# Altium schematic sheet RF_Rev0.SchDoc(RF_Rev0)
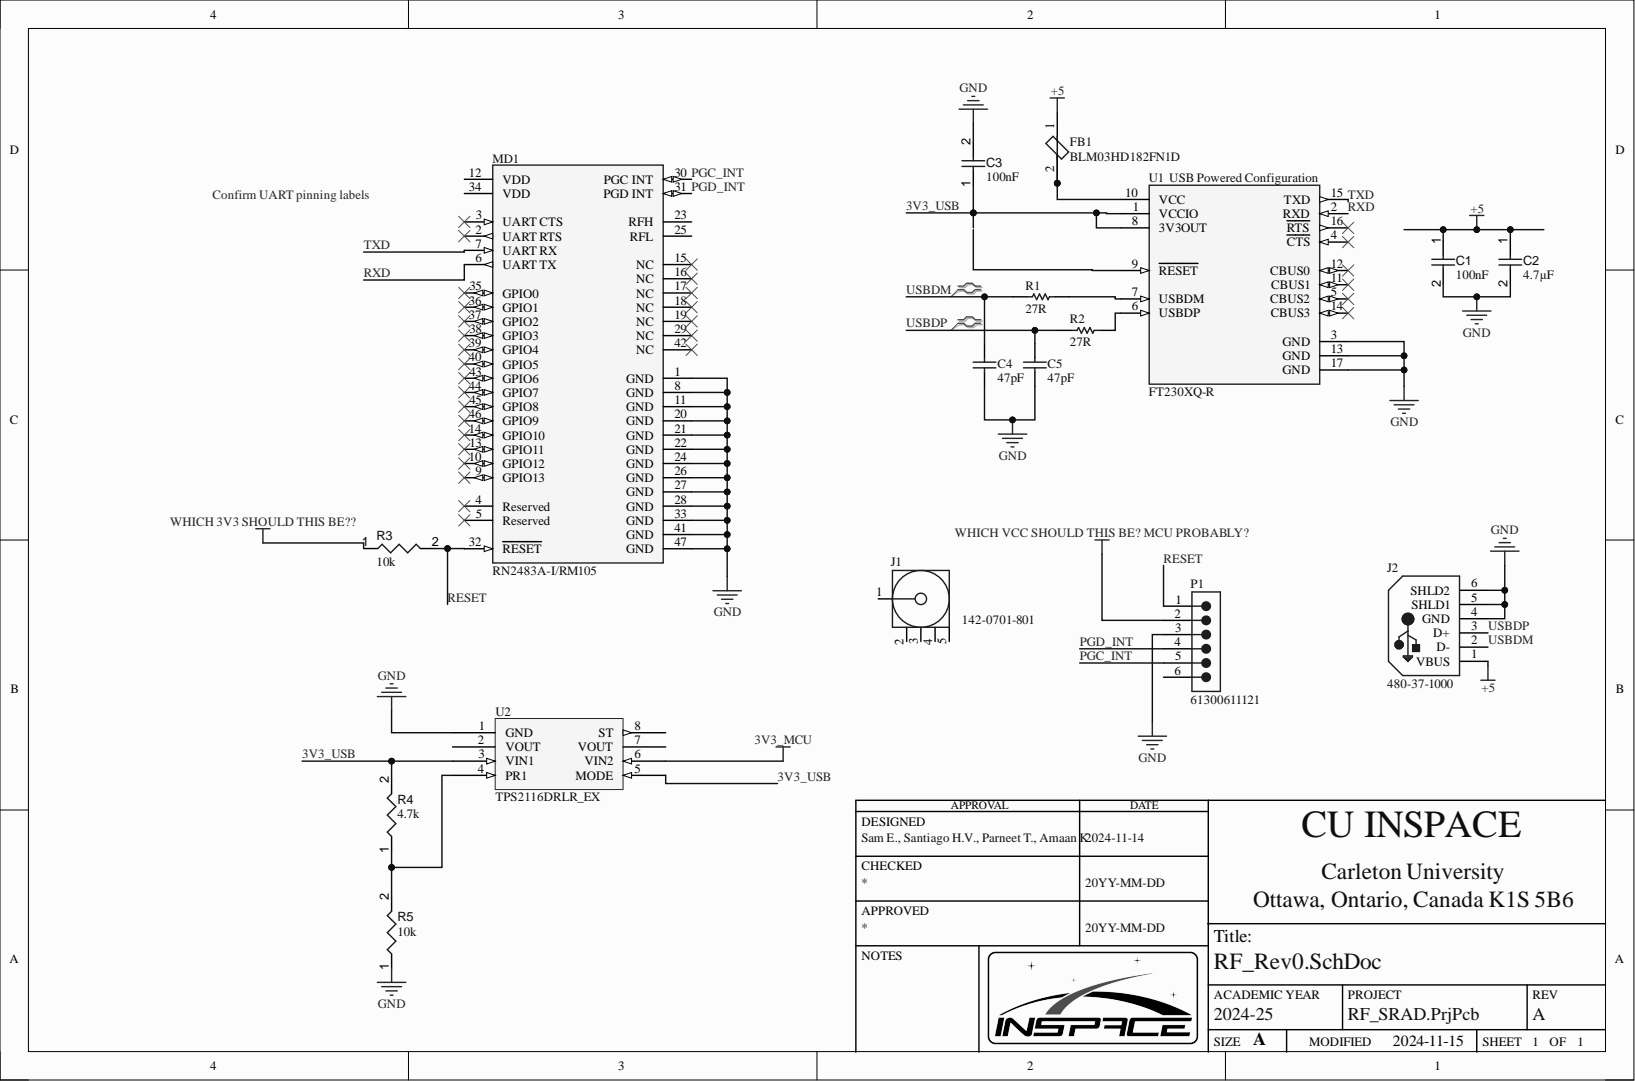
[diagram p0: RF_Rev0.SchDoc]

## Parts
C1  value 100nF  [CAPC0402(1005)60_L]  (hidden comment: Capacitor 100nF +/-20% 25V 0402)
C2  value 4.7µF  [CAPC0603(1608)100_L]  (hidden comment: Capacitor 4.7µF +/-20% 10V 0603)
C3  value 100nF  [CAPC0402(1005)60_L]  (hidden comment: Capacitor 100nF +/-20% 25V 0402)
C4  47pF  [CAPC1005X55X25LL05T10]
C5  47pF  [CAPC1005X55X25LL05T10]
FB1  BLM03HD182FN1D  [FP-BLM03-0_03-d0_3-IPC_A]
J1  142-0701-801  [CINC-142-0701-801_V]
J2  480-37-1000  [MOLX-480-37-1000_V]
MD1  RN2483A-I/RM105  [FP-RN2483A-I-RM105-MFG]
P1  61300611121  [61300611121]
R1  27R  [RESC1005X40X25LL05T10]
R2  27R  [RESC1005X40X25LL05T10]
R3  value 10k  [RESC1005X40X25ML05T05]  (hidden comment: Resistor 10k +/-1% 0402 63 mW)
R4  value 4.7k  [RESC3116X70X50ML13T20]  (hidden comment: Resistor 4k7 +/-1% 1206 250 mW)
R5  value 10k  [RESC1608X55X25ML10T15]  (hidden comment: Resistor 10k +/-1% 0603 100 mW)
U1  FT230XQ-R  Interface: USB  [FP-QFN-16-MFG]
U2  TPS2116DRLR_EX  [TPS2115]

## Nets
3V3_MCU: U2.6(VIN2)
+5: C1.1 C2.1 FB1.1 J2.1(VBUS)
GND: C1.2 C2.2 C3.2 C4.1 C5.1 J2.4(GND) J2.5(SHLD1) J2.6(SHLD2) MD1.1(GND) MD1.8(GND) MD1.11(GND) MD1.20(GND) MD1.21(GND) MD1.22(GND) MD1.24(GND) MD1.26(GND) MD1.27(GND) MD1.28(GND) MD1.33(GND) MD1.41(GND) MD1.47(GND) P1.3 R5.1 U1.3(GND) U1.13(GND) U1.17(GND) U2.1(GND)
WHICH 3V3 SHOULD THIS BE??: R3.1
WHICH VCC SHOULD THIS BE? MCU PROBABLY?: P1.2
3V3_USB: C3.1 R4.2 U1.1(VCCIO) U1.8(3V3OUT) U2.3(VIN1) U2.5(MODE)
PGC_INT: MD1.30(PGC_INT) P1.5
PGD_INT: MD1.31(PGD_INT) P1.4
RESET: MD1.32(RESET) P1.1 R3.2
RXD: MD1.6(UART_TX) U1.2(RXD)
TXD: MD1.7(UART_RX) U1.15(TXD)
USBDM: C4.2 J2.2(D-) R1.1
USBDP: C5.2 J2.3(D+) R2.1
NetFB1_2: FB1.2 U1.10(VCC)
NetR1_2: R1.2 U1.7(USBDM)
NetR2_2: R2.2 U1.6(USBDP)
NetR4_1: R4.1 R5.2 U2.4(PR1)
Confirm UART pinning labels:

Not connected: J1 1 2 3 4 5; MD1 2(UART_RTS) 3(UART_CTS) 4(Reserved) 5(Reserved) 9(GPIO13) 10(GPIO12) 12(VDD) 13(GPIO11) 14(GPIO10) 15(NC) 16(NC) 17(NC) 18(NC) 19(NC) 23(RFH) 25(RFL) 29(NC) 34(VDD) 35(GPIO0) 36(GPIO1) 37(GPIO2) 38(GPIO3) 39(GPIO4) 40(GPIO5) 42(NC) 43(GPIO6) 44(GPIO7) 45(GPIO8) 46(GPIO9); P1 6; U1 4(CTS) 5(CBUS2) 9(RESET) 11(CBUS1) 12(CBUS0) 14(CBUS3) 16(RTS); U2 2(VOUT) 7(VOUT) 8(ST)

## Library data of the parts
C1 C3 = CMP-001-00065-4: Chip Capacitor, 100nF +/-20%, 25V, 0402, Thickness 0.6 mm; Tolerance: 10%; Case/Package: 0402; Component Kind: Standard; Max Operating Temperature: 85°C; Min Operating Temperature: -55°C; Mounting Technology: SMT; Pins: 2; Voltage Rating: 25V; Library Name: Americas- CU InSpace-  Carleton University
C2 = CMP-001-00076-4: Chip Capacitor, 4.7µF +/-20%, 10V, 0603, Thickness 1 mm; Tolerance: 10%; Case/Package: 0603; Component Kind: Standard; Max Operating Temperature: 85°C; Min Operating Temperature: -55°C; Mounting Technology: SMT; Pins: 2; Voltage Rating: 10V; Library Name: Americas- CU InSpace-  Carleton University
C4 C5 = CMP-2008-04368-1: Manufacturer: Murata; Part Number: GRM1555C1H470JA01D; Component Kind: Standard; Library Name: Altium Content Vault
FB1 = CMP-06046-001880-1: Ferrite Bead, 0201, 1800Ω @ 100MHz, 25%, 3.8Ω, 100mA; DC Resistance (DCR): 3.8R; Number of Lines: 1; Case Code (Imperial): 0201; Case Code (Metric): 0603; Case/Package: 0201; Component Kind: Standard; Library Name: Altium Content Vault; Max Current Rating: 100mA; Max Operating Temperature: 125°C; Min Operating Temperature: -55°C; Mount: Surface Mount; Packaging: Tape and Reel; RoHS Compliant: Yes
J1 = CMP-2000-05490-1: SMA End Launch Jack Receptacle, 50 Ohm, 0 to 18 GHz, -65 to 165 degC, 5-Pin SMD, RoHS, Bulk; Manufacturer: EmersonConnectivitySolutions; Body Material: Brass; Body Style: Straight; Brand: Johnson/EmersonConnectivitySolutions; Connector Type: JackPCB; Gender: Jack(Female); Impedance: 50Ohms; Maximum Frequency: 18GHz; Component Kind: Standard; Contact Plating: Gold; Datasheet URL: https://belfuse.com/resources/Johnson/productinformation/pi-142-0701-801.pdf; Datasheet Version: 12/2014; Factory Pack Quantity: 5; Library Name: Altium Content Vault; Manufacturer URL: http://www.cinch.com/; Mounting Technology: SurfaceMount; Operating Temperature Range: -65Cto+165C; Orientation: Straight; Package Description: 5-Pin Surface Mount Device, Body 14.275 x 9.525 mm; Package Reference: 142-0701-801; Package URL: https://belfuse.com/resources/Johnson/drawings/dr-1420701801.pdf; Product: Connectors; Product Category: RFConnectors/CoaxialConnectors; RF Series: SMA; RoHS: Details; Series: SMA; Termination Style: Solder
J2 = CMP-002-00040-2: Universal Serial Bus (USB) Shielded I/O Plug, Type A, Right Angle Connector, 4 Position, Height 4.6 mm, -20 to 85 degC, RoHS, Tray; Manufacturer: Molex; Current Rating: 1.5A; Gender: Male; Max Voltage Rating (AC): 150V; Case/Package: 480-37-1000; Colour: Black; Component Kind: Standard; Connector Type: USB; Contact Material: Brass; Contact Plating: Gold; Datasheet URL: http://www.molex.com/pdm_docs/sd/480371000_sd.pdf; Datasheet Version: Rev. D, 06/2004; Manufacturer URL: http://www.molex.com/; Max Current Rating: 1.5A; Max Operating Temperature: 85°C; Min Operating Temperature: -20°C; Mounting Technology: SM; Package Description: 4-Pin Surface Mount Device, Body 12 x 18.8 mm; Package Reference: 480-37-1000; Packaging: Tray; Pins: 4; RoHS Compliant: True; Voltage Rating (AC): 150V; Library Name: Americas- CU InSpace-  Carleton University
MD1 = CMP-00025-00003-1: RF Transceiver Module FSK/GFSK/LoRa 433MHz and 868MHz 2.1V to 3.6V SMD Tray; Component Kind: Standard; Library Name: Americas- CU InSpace-  Carleton University
P1 = CMP-002-00089-1: Board Connector, 6 Contact(s), 1 Row(s), Male, Straight, 0.1 inch Pitch, Solder Terminal, Locking, Black Insulator, Plug; Component Kind: Standard; Connector Type: BOARD CONNECTOR; Max Operating Temperature: 125°C; Min Operating Temperature: -40°C; Mounting Technology: Board; RoHS Compliant: Yes; Library Name: Americas- CU InSpace-  Carleton University
R1 R2 = CMP-2002-07055-1: Manufacturer: Yageo / Phycomp; Part Number: RC0402FR-0727RL; Component Kind: Standard; Library Name: Altium Content Vault
R3 = CMP-009-00019-6: Chip Resistor, 10 KOhm, +/- 1%, 63 mW, -55 to 155 degC, 0402 (1005 Metric); Power: 63mW; Voltage Rating: 50V; Case/Package: 0402; Component Kind: Standard; Max Operating Temperature: 155°C; Min Operating Temperature: -55°C; Mounting Technology: SM; Pins: 2; RoHS Compliant: True; Tolerance: 1%; Library Name: Americas- CU InSpace-  Carleton University
R4 = CMP-009-00033-6: Chip Resistor, 4.7 KOhm, +/- 1%, 0.25 W, -55 to 155 degC, 1206 (3216 Metric); Power: 250mW; Voltage Rating: 200V; Case/Package: 1206; Component Kind: Standard; Max Operating Temperature: 155°C; Min Operating Temperature: -55°C; Mounting Technology: SM; Pins: 2; RoHS Compliant: True; Tolerance: 1%; Library Name: Americas- CU InSpace-  Carleton University
R5 = CMP-009-00017-6: Chip Resistor, 10 KOhm, +/- 1%, 01 W, -55 to 155 degC, 0603 (1608 Metric); Power: 100mW; Voltage Rating: 50V; Case/Package: 0603; Component Kind: Standard; Max Operating Temperature: 155°C; Min Operating Temperature: -55°C; Mounting Technology: SM; Pins: 2; RoHS Compliant: True; Tolerance: 1%; Library Name: Americas- CU InSpace-  Carleton University
U1 = CMP-0248-00010-3: IC USB SERIAL BASIC UART 16QFN; Data Rate: 12Mbps; Max Supply Voltage: 5.5V; Min Supply Voltage: 2.97V; Number of Channels: 1; Operating Supply Current: 8mA; Operating Supply Voltage: 5V; Case/Package: QFN; Component Kind: Standard; Height: 0.75mm; Lead Free: Lead Free; Length: 4mm; Library Name: Altium Content Vault; Max Operating Temperature: 85°C; Min Operating Temperature: -40°C; Mount: Surface Mount; Number of Pins: 16; Package Quantity: 5000; Packaging: Tape and Reel; Weight: 0.002014oz; Width: 4mm
U2 = CMP-00025-4: 1.6-V to 5.5-V, 40-mΩ, 2.5-A, low-IQ, priority power multiplexer 8-SOT-5X3 -40 to 125; Component Kind: Standard; Library Name: Americas- CU InSpace-  Carleton University
